# Altium schematic sheet [06] - AUDIO, MIC AND SERVO.SchDoc("[06] - AUDIO, MIC AND SERVO")
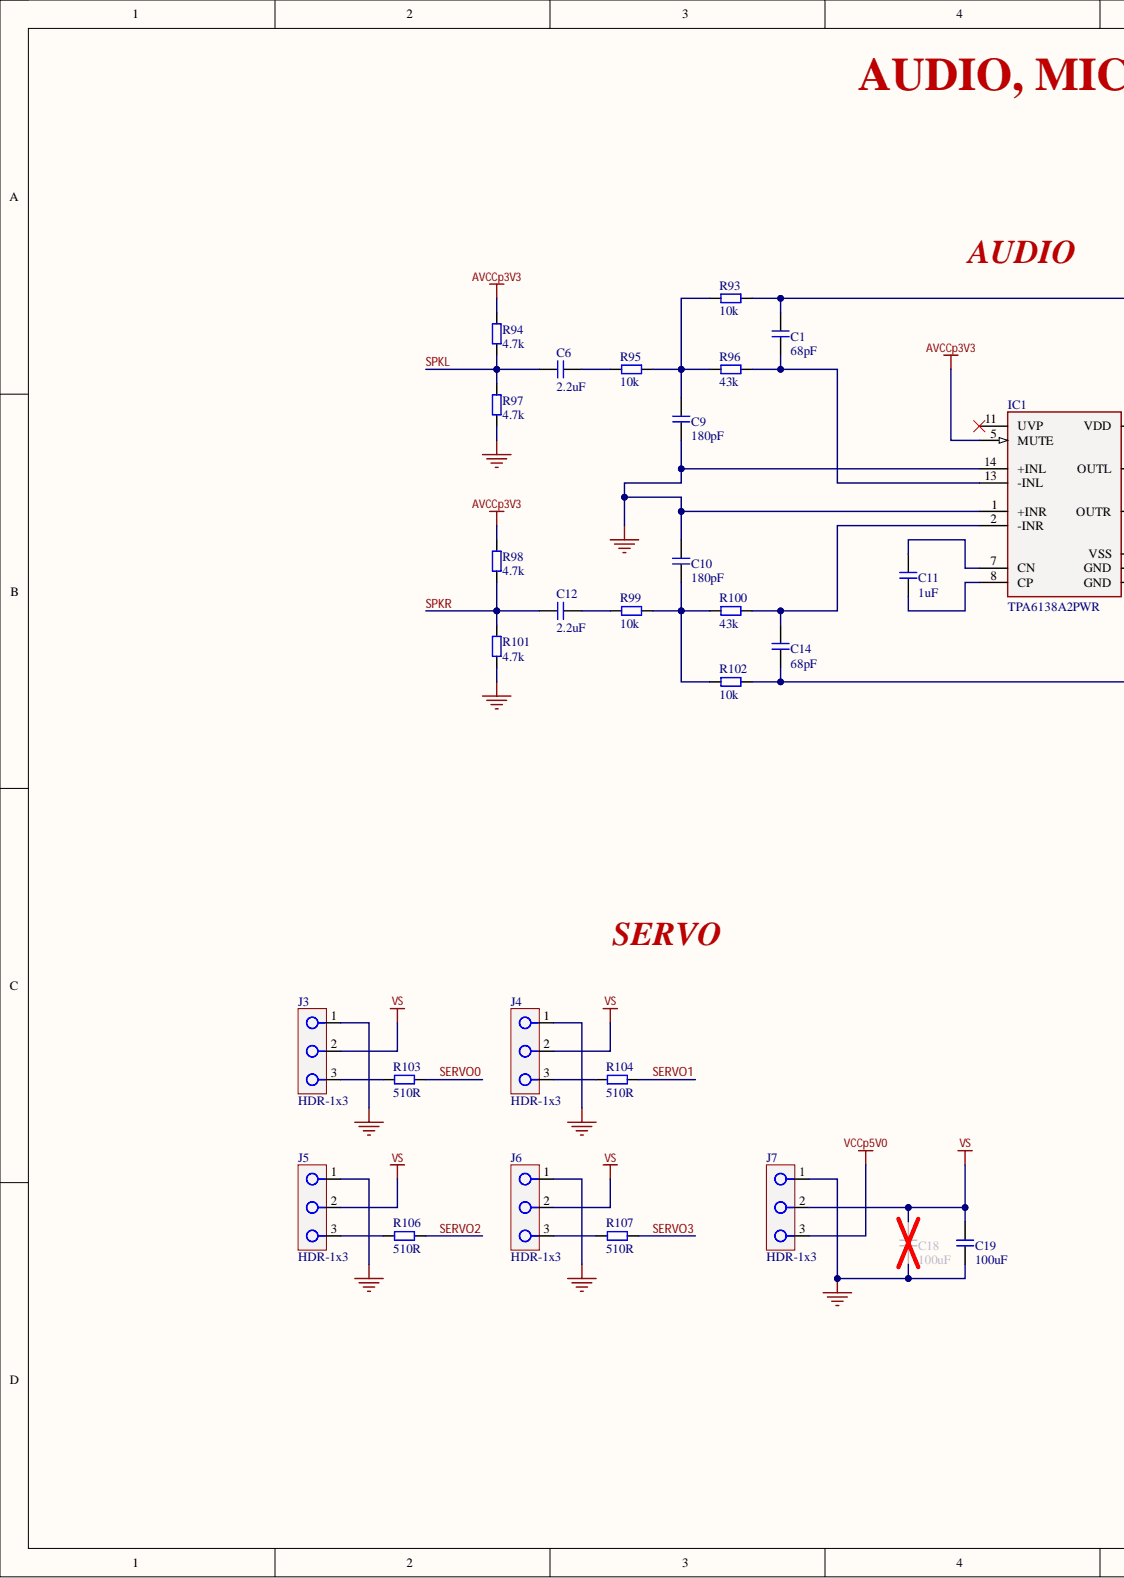
[diagram p0: [06] - AUDIO, MIC AND SERVO.SchDoc, left]
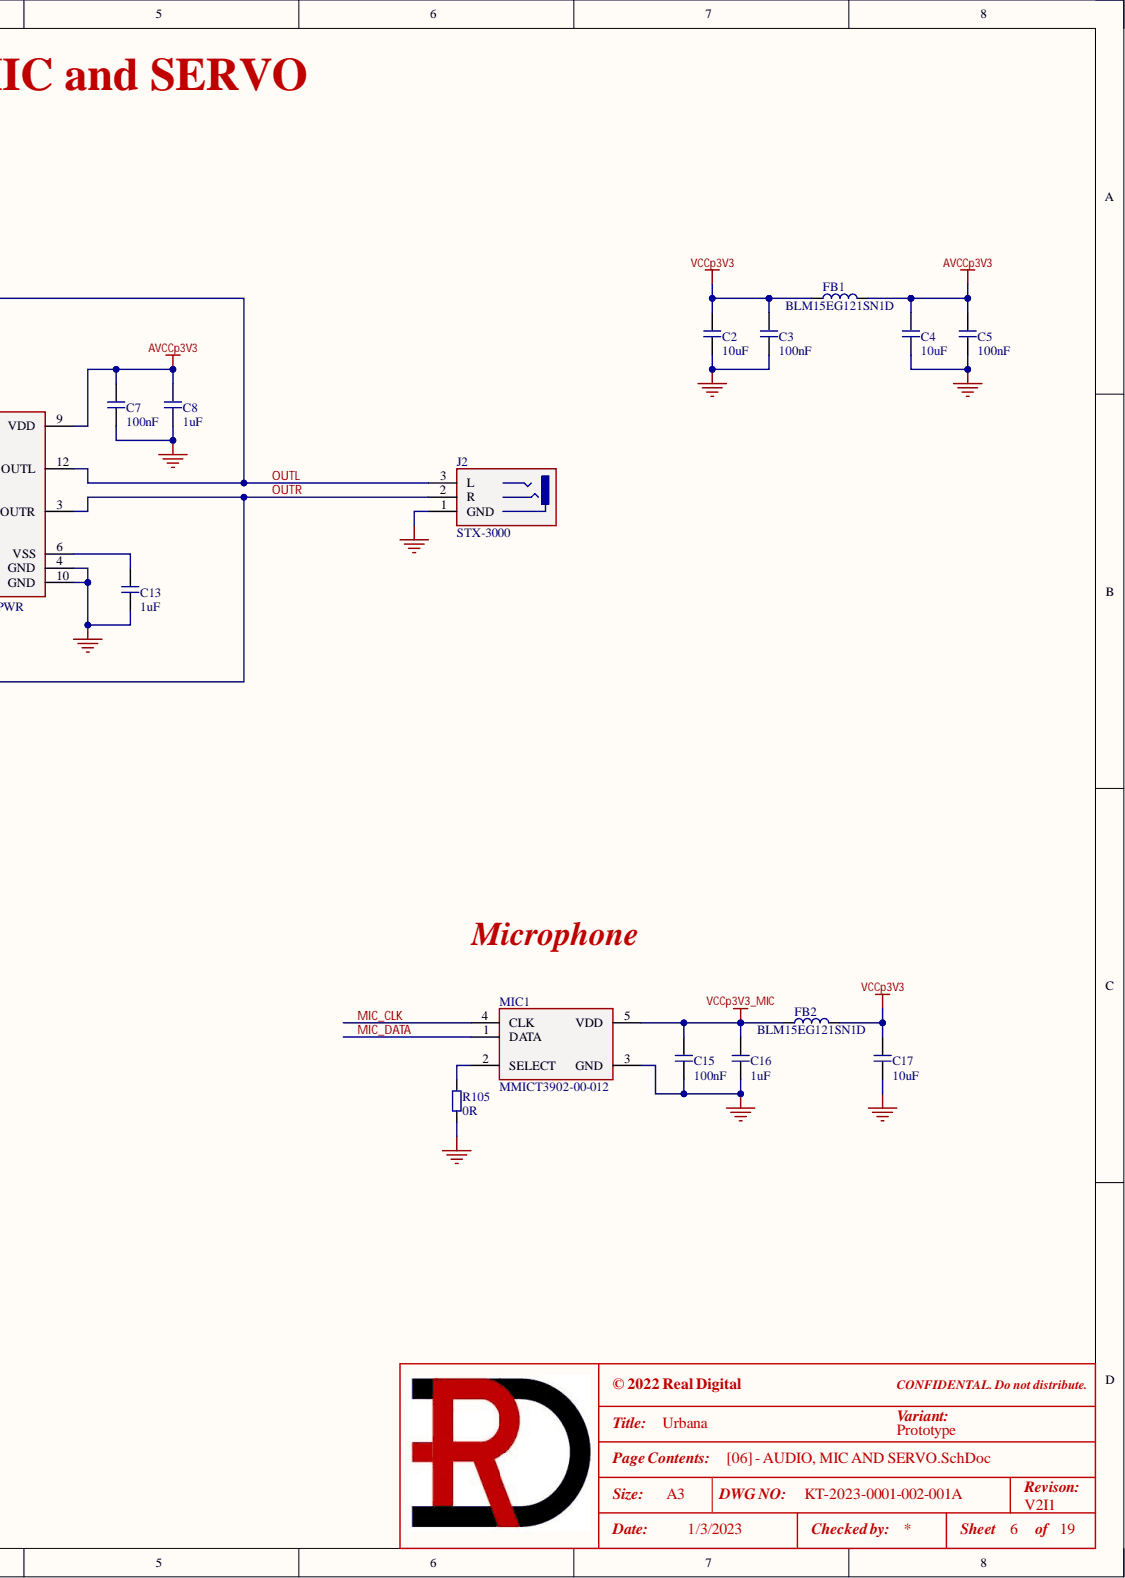
[diagram p1: [06] - AUDIO, MIC AND SERVO.SchDoc, right]

## Parts
C1  68pF  [C0603]
C2  10uF  [C0402]
C3  100nF  [C0402]
C4  10uF  [C0402]
C5  100nF  [C0402]
C6  2.2uF  [C0603]
C7  100nF  [C0402]
C8  1uF  [C0603]
C9  180pF  [C0603]
C10  180pF  [C0603]
C11  1uF  [C0603]
C12  2.2uF  [C0603]
C13  1uF  [C0603]
C14  68pF  [C0603]
C15  100nF  [C0402]
C16  1uF  [C0402]
C17  10uF  [C0402]
C18  100uF  [C1210]
C19  100uF  [C1210]
FB1  BLM15EG121SN1D  [BLM15]
FB2  BLM15EG121SN1D  [BLM15]
IC1  TPA6138A2PWR  [PW0014A_TSSOP14 - TI]
J2  STX-3000  [PJD-035-27SDB]
J3  HDR-1x3  [HDR1X3]
J4  HDR-1x3  [HDR1X3]
J5  HDR-1x3  [HDR1X3]
J6  HDR-1x3  [HDR1X3]
J7  HDR-1x3  [HDR1X3]
MIC1  MMICT3902-00-012  [T3902 - TDK_InvenSense]
R93  10k  [R0402]
R94  4.7k  [R0402]
R95  10k  [R0402]
R96  43k  [R0402]
R97  4.7k  [R0402]
R98  4.7k  [R0402]
R99  10k  [R0402]
R100  43k  [R0402]
R101  4.7k  [R0402]
R102  10k  [R0402]
R103  510R  [R0402]
R104  510R  [R0402]
R105  0R  [R0402]
R106  510R  [R0402]
R107  510R  [R0402]

## Nets
AVCCp3V3: C4.2 C5.2 C7.2 C8.2 FB1.2 IC1.5(MUTE) IC1.9(VDD) R94.2 R98.2
GND: C2.1 C3.1 C4.1 C5.1 C7.1 C8.1 C9.1 C10.2 C13.1 C15.1 C16.1 C17.1 C18.1 C19.1 IC1.1(+INR) IC1.4(GND) IC1.10(GND) IC1.14(+INL) J2.1 J3.1 J4.1 J5.1 J6.1 J7.1 MIC1.3(GND) R97.1 R101.1 R105.1
VCCp3V3: C2.2 C3.2 C17.2 FB1.1 FB2.2
VCCp3V3_MIC: C15.2 C16.2 FB2.1 MIC1.5(VDD)
VCCp5V0: J7.3
VS: C18.2 C19.2 J3.2 J4.2 J5.2 J6.2 J7.2
MIC_CLK: MIC1.4(CLK)
MIC_DATA: MIC1.1(DATA)
OUTL: C1.2 IC1.12(OUTL) J2.3 R93.2
OUTR: C14.2 IC1.3(OUTR) J2.2 R102.2
SERVO0: R103.2
SERVO1: R104.2
SERVO2: R106.2
SERVO3: R107.2
SPKL: C6.1 R94.1 R97.2
SPKR: C12.1 R98.1 R101.2
NetC1_1: C1.1 IC1.13(-INL) R96.2
NetC6_2: C6.2 R95.1
NetC9_2: C9.2 R93.1 R95.2 R96.1
NetC10_1: C10.1 R99.2 R100.1 R102.1
NetC11_1: C11.1 IC1.8(CP)
NetC11_2: C11.2 IC1.7(CN)
NetC12_2: C12.2 R99.1
NetC13_2: C13.2 IC1.6(VSS)
NetC14_1: C14.1 IC1.2(-INR) R100.2
NetJ3_3: J3.3 R103.1
NetJ4_3: J4.3 R104.1
NetJ5_3: J5.3 R106.1
NetJ6_3: J6.3 R107.1
NetMIC1_2: MIC1.2(SELECT) R105.2

Not connected: IC1 11(UVP)

## Library data of the parts
C1 C14 = CC0603JRNPO9BN680: CAP CER 68PF 50V C0G/NPO 0603; Manufacturer 1: Yageo; .Checked_PCB: AC; .Creator: RV; C P/N: RD-111-0001; LoadStatus: Load; Temperature Coefficient: C0G, NP0; Tolerance: 5%; Category: Capacitors; Component Kind: Standard; Library Name: KT-RealDigital.IntLib; Manufacturer 1 Part Number: CC0603JRNPO9BN680; Voltage - Rated: 50V; .Checked_SCH: AC
C2 C4 C17 = GRM155R60J106ME47D: CAP CER 10uF 6.3V X5R 0402; Manufacturer 1: Murata Electronics; .Checked_PCB: RV; LoadStatus: Load; Tolerance: 20%; Category: Capacitors; Component Kind: Standard; Library Name: KT-RealDigital.IntLib; Manufacturer 1 Part Number: GRM155R60J106ME47D; Temperature Coefficient: X5R; Voltage - Rated: 6.3V; .Checked_SCH: RV; .Creator: RV
C3 C5 C7 C15 = GRM155R71E104KE14J: CAP CER 0.1uF 25V X7R 0402; Manufacturer 1: Murata Electronics; .Checked_PCB: AC; .Creator: RV; C P/N: RD-111-0006; LoadStatus: Load; Tolerance: 10%; Category: Capacitors; Component Kind: Standard; Library Name: KT-RealDigital.IntLib; Manufacturer 1 Part Number: GRM155R71E104KE14J; Temperature Coefficient: X7R; Voltage - Rated: 25V; .Checked_SCH: AC
C6 C12 = GRM188R61E225KA12D: CAP CER 2.2uF 25V X5R 0603; Manufacturer 1: Murata Electronics; .Checked_PCB: AC; .Creator: RV; C P/N: 111-0404; LoadStatus: Load; Tolerance: 10%; Category: Capacitors; Component Kind: Standard; Library Name: KT-RealDigital.IntLib; Manufacturer 1 Part Number: GRM188R61E225KA12D; Temperature Coefficient: X5R; Voltage - Rated: 25V; .Checked_SCH: AC
C8 C11 C13 = GCJ188R71E105KA01D: CAP CER 1uF 25V X7R 0603; Manufacturer 1: Murata Electronics; .Checked_PCB: AC; .Creator: RV; C P/N: 111-0602; LoadStatus: Load; Tolerance: 10%; Category: Capacitors; Component Kind: Standard; Library Name: KT-RealDigital.IntLib; Manufacturer 1 Part Number: GCJ188R71E105KA01D; Temperature Coefficient: X7R; Voltage - Rated: 25V; .Checked_SCH: AC
C9 C10 = CC0603JRNPO9BN181: CAP CER 180PF 50V C0G/NPO 0603; Manufacturer 1: Yageo; .Checked_PCB: AC; .Creator: RV; C P/N: RD-111-0009; LoadStatus: Load; Temperature Coefficient: C0G, NP0; Tolerance: 5%; Category: Capacitors; Component Kind: Standard; Library Name: KT-RealDigital.IntLib; Manufacturer 1 Part Number: CC0603JRNPO9BN181; Voltage - Rated: 50V; .Checked_SCH: AC
C16 = GRM155R70J105KA12J: CAP CER 1uF 6.3V X7R 0402; Manufacturer 1: Murata Electronics; .Checked_PCB: RV; LoadStatus: Load; Tolerance: 10%; Category: Capacitors; Component Kind: Standard; Library Name: KT-RealDigital.IntLib; Manufacturer 1 Part Number: GRM155R70J105KA12J; Temperature Coefficient: X7R; Voltage - Rated: 6.3V; .Checked_SCH: RV; .Creator: RV
C18 C19 = GRM32ER61A107ME20L: CAP CER 100uF 10V X5R 1210; Manufacturer 1: Murata Electronics; .Checked_PCB: AC; .Creator: RV; C P/N: RD-111-0004; LoadStatus: Load; Tolerance: 20%; Category: Capacitors; Component Kind: Standard; Library Name: KT-RealDigital.IntLib; Manufacturer 1 Part Number: GRM32ER61A107ME20L; Temperature Coefficient: X5R; Voltage - Rated: 10V; .Checked_SCH: AC
FB1 FB2 = BLM15EG121SN1D: FERRITE BEAD 120 OHM 0402 1LN; Manufacturer 1: Murata Electronics; .Checked_PCB: AC; .Creator: RV; C P/N: 131-0493; LoadStatus: Load; Category: Ferrite Beads; Component Kind: Standard; Library Name: KT-RealDigital.IntLib; Manufacturer 1 Part Number: BLM15EG121SN1D; .Checked_SCH: AC
IC1 = TPA6138A2PWR: IC AMP CLSS AB STER 40MW 14TSSOP; Manufacturer 1: Texas Instruments; .Checked_PCB: RV; C P/N: CML-126-0051; LoadStatus: Load; Category: IC; Component Kind: Standard; Library Name: KT-RealDigital.IntLib; Manufacturer 1 Part Number: TPA6138A2PWR; .Checked_SCH: RV; .Creator: RV
J2 = STX-3000: 3.50mm (0.141", 1/8", Mini Plug) - Headphone Phone Jack Stereo (3 Conductor, TRS) Connector Kinked Pin; Manufacturer 1: Kycon, Inc.; .Checked_PCB: AC; .Creator: RV; C P/N: 163-0000; LoadStatus: Load; Category: Connector; Component Kind: Standard; Library Name: KT-RealDigital.IntLib; Manufacturer 1 Part Number: STX-3000; Manufacturer 2: JKCR Electronics Co., Ltd.; Manufacturer 2 Part Number: PJD-035-27SDB; .Checked_SCH: AC
J3-J7 = HDR-1x3: Header 2.54mm, 1x3; Manufacturer 1: Centronic Precision Electronic; Manufacturer 1 Part Number: H6-80-03; .Checked_PCB: AC; .Creator: RV; C P/N: 163-0035; LoadStatus: Load; Category: Header; Component Kind: Standard; Library Name: KT-RealDigital.IntLib; .Checked_SCH: AC
MIC1 = MMICT3902-00-012: PDM DIGITAL OUTPUT MEMS MICROPHONE; Manufacturer 1: TDK InvenSense; .Checked_PCB: RV; LoadStatus: Load; Category: Microphones; Component Kind: Standard; Library Name: KT-RealDigital.IntLib; Manufacturer 1 Part Number: MMICT3902-00-012; .Checked_SCH: RV; .Creator: RV
R93 R95 R99 R102 = RT0402BRB0710KL: RES SMD 10K OHM 0.1% 1/16W 0402; Manufacturer 1: Yageo; .Checked_PCB: AC; .Creator: RV; C P/N: 101-0862; LoadStatus: Load; Category: Resistors; Component Kind: Standard; Library Name: KT-RealDigital.IntLib; Manufacturer 1 Part Number: RT0402BRB0710KL; Tolerance: 0.1%; .Checked_SCH: AC
R94 R97 R98 R101 = RC0402FR-074K7P: RES SMD 4.7K OHM 1% 1/16W 0402; Manufacturer 1: Yageo; .Checked_PCB: AC; .Creator: RV; C P/N: 101-0129; LoadStatus: Load; Category: Resistors; Component Kind: Standard; Library Name: KT-RealDigital.IntLib; Manufacturer 1 Part Number: RC0402FR-074K7P; Tolerance: 1%; .Checked_SCH: AC
R96 R100 = RC0402FR-0743KL: RES SMD 43K OHM 1% 1/16W 0402; Manufacturer 1: Yageo; .Checked_PCB: AC; .Creator: RV; C P/N: RD-101-0001; LoadStatus: Load; Category: Resistors; Component Kind: Standard; Library Name: KT-RealDigital.IntLib; Manufacturer 1 Part Number: RC0402FR-0743KL; Tolerance: 1%; .Checked_SCH: AC
R103 R104 R106 R107 = RC0402JR-0751RL: RES SMD 51 OHM 5% 1/16W 0402; Manufacturer 1: Yageo; .Checked_PCB: AC; .Creator: RV; C P/N: 101-0040; LoadStatus: Load; Category: Resistors; Component Kind: Standard; Library Name: KT-RealDigital.IntLib; Manufacturer 1 Part Number: RC0402JR-0751RL; Tolerance: 5%; .Checked_SCH: AC
R105 = RC0402FR-070RL: RES SMD 0 OHM JUMPER 1/16W 0402; Manufacturer 1: Yageo; .Checked_PCB: AC; .Creator: RV; C P/N: RD-101-0003; LoadStatus: Load; Category: Resistors; Component Kind: Standard; Library Name: KT-RealDigital.IntLib; Manufacturer 1 Part Number: RC0402FR-070RL; Tolerance: Jumper; .Checked_SCH: AC
